# Altium schematic sheet CAN.SchDoc
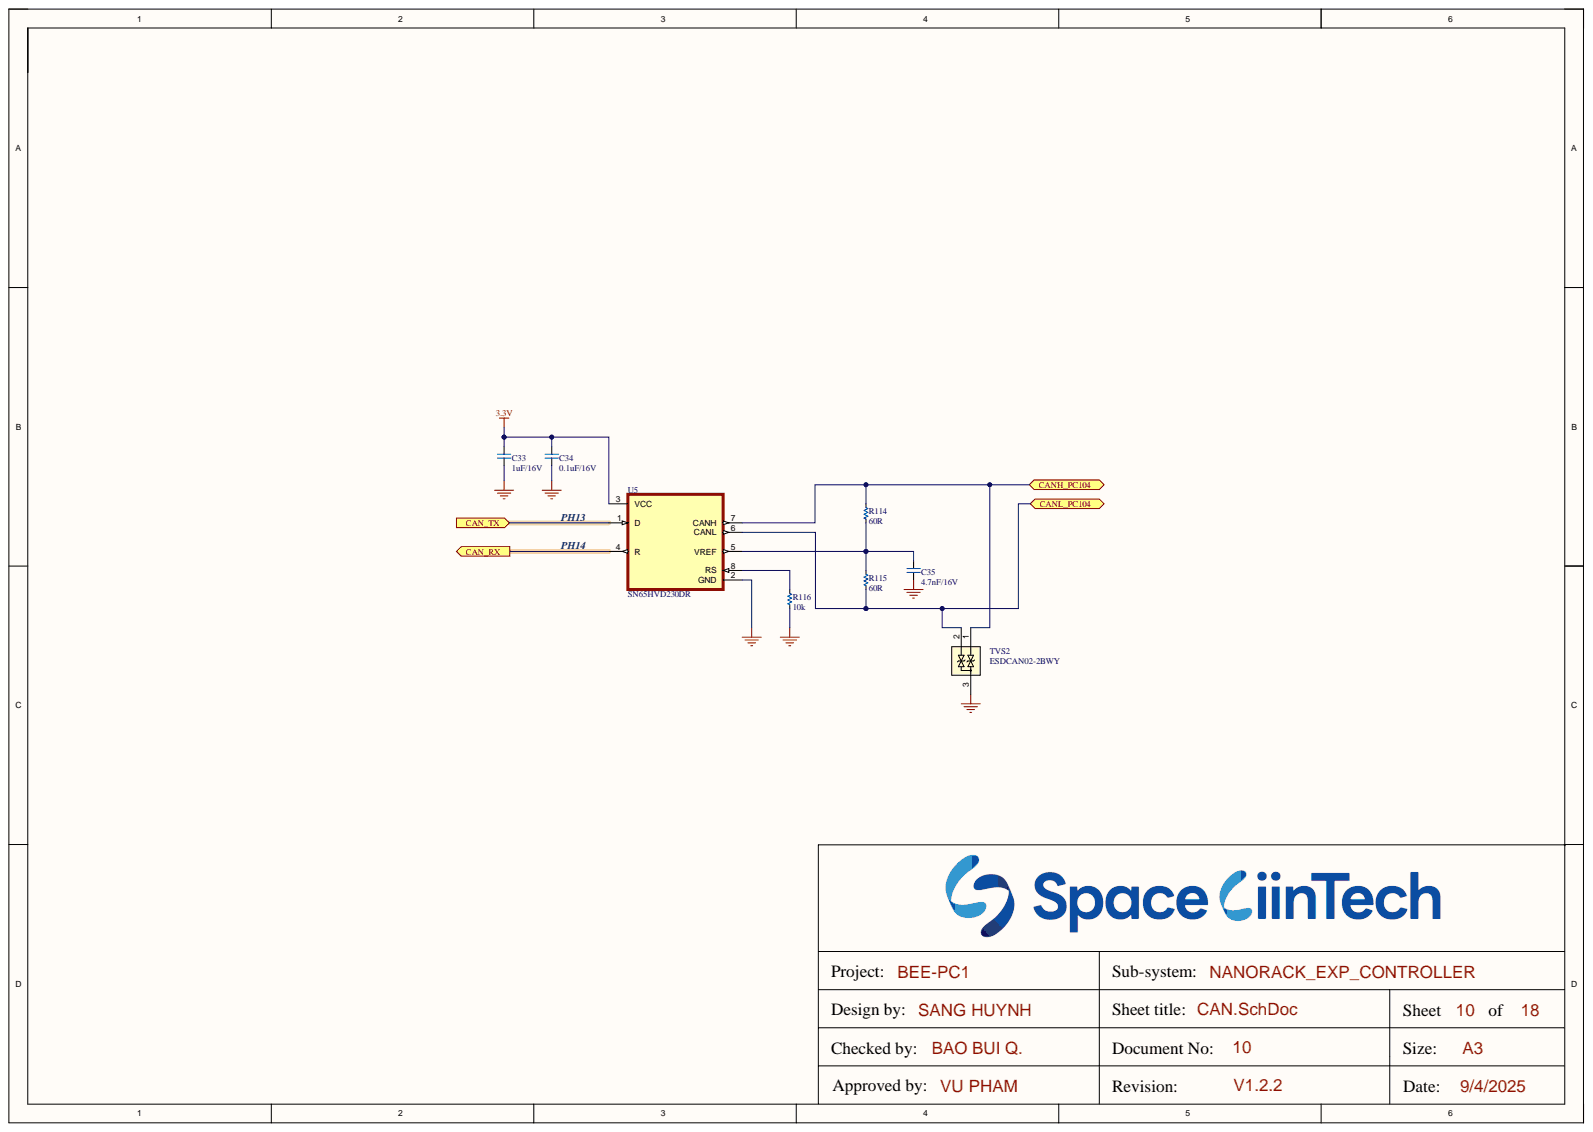
[diagram p0: CAN.SchDoc]

## Parts
C33  1uF/16V  [CAP_C_1608X80]
C34  0.1uF/16V  [CAP_C_1608X80]
C35  4.7nF/16V  [CAP_C_1608X80]
R114  60R  [RES_C_1608X60]
R115  60R  [RES_C_1608X60]
R116  10k  [RES_C_1608X60]
TVS2  ESDCAN02-2BWY  [SOT323-3L_STM]
U5  SN65HVD230DR  [SOIC127P599X175-8N]

## Ports
CAN_RX, CAN_TX, CANH_PC104, CANL_PC104

## Nets
3.3V: C33.1 C34.1 U5.3(VCC)
GND: C33.2 C34.2 C35.2 R116.1 TVS2.3 U5.2(GND)
CAN_RX: U5.4(R) ; port CAN_RX
CAN_TX: U5.1(D) ; port CAN_TX
CANH_PC104: R114.2 TVS2.1 U5.7(CANH) ; port CANH_PC104
CANL_PC104: R115.1 TVS2.2 U5.6(CANL) ; port CANL_PC104
NetC35_1: C35.1 R114.1 R115.2 U5.5(VREF)
NetR116_2: R116.2 U5.8(RS)

## Library data of the parts
C33-C35 = CAP_SMD: CAP_Chip; Value: 33pF; Component Kind: Standard; Library Name: LABLIB.SchLib
R114-R116 = _RES_B_2: RES; Component Kind: Standard; Library Name: LABLIB.SchLib
TVS2 = ESDCAN02-2BWY: Automotive dual-line TVS in SOT323-3L for CAN bus (12 V system); Manufacturer_Name: STMicroelectronics; Component Kind: Standard; Manufacturer_Part_Number: ESDCAN02-2BWY; RefDes: RefDes; Type: Type; Copyright: Copyright (C) 2025 Ultra Librarian. All rights reserved.; Library Name: COMM_SCHLIB.SchLib
U5 = SN65HVD230DR: 3.3 V CAN Transceiver with Standby Mode; MF: Texas Instruments; Check_prices: https://www.snapeda.com/parts/SN65HVD230DR/Texas+Instruments/view-part/?ref=eda; Component Kind: Standard; MP: SN65HVD230DR; Package: SOIC-8 Texas Instruments; SnapEDA_Link: https://www.snapeda.com/parts/SN65HVD230DR/Texas+Instruments/view-part/?ref=snap; Library Name: COMM_SCHLIB.SchLib
